# Altium schematic sheet Power Sense Filtering.SchDoc
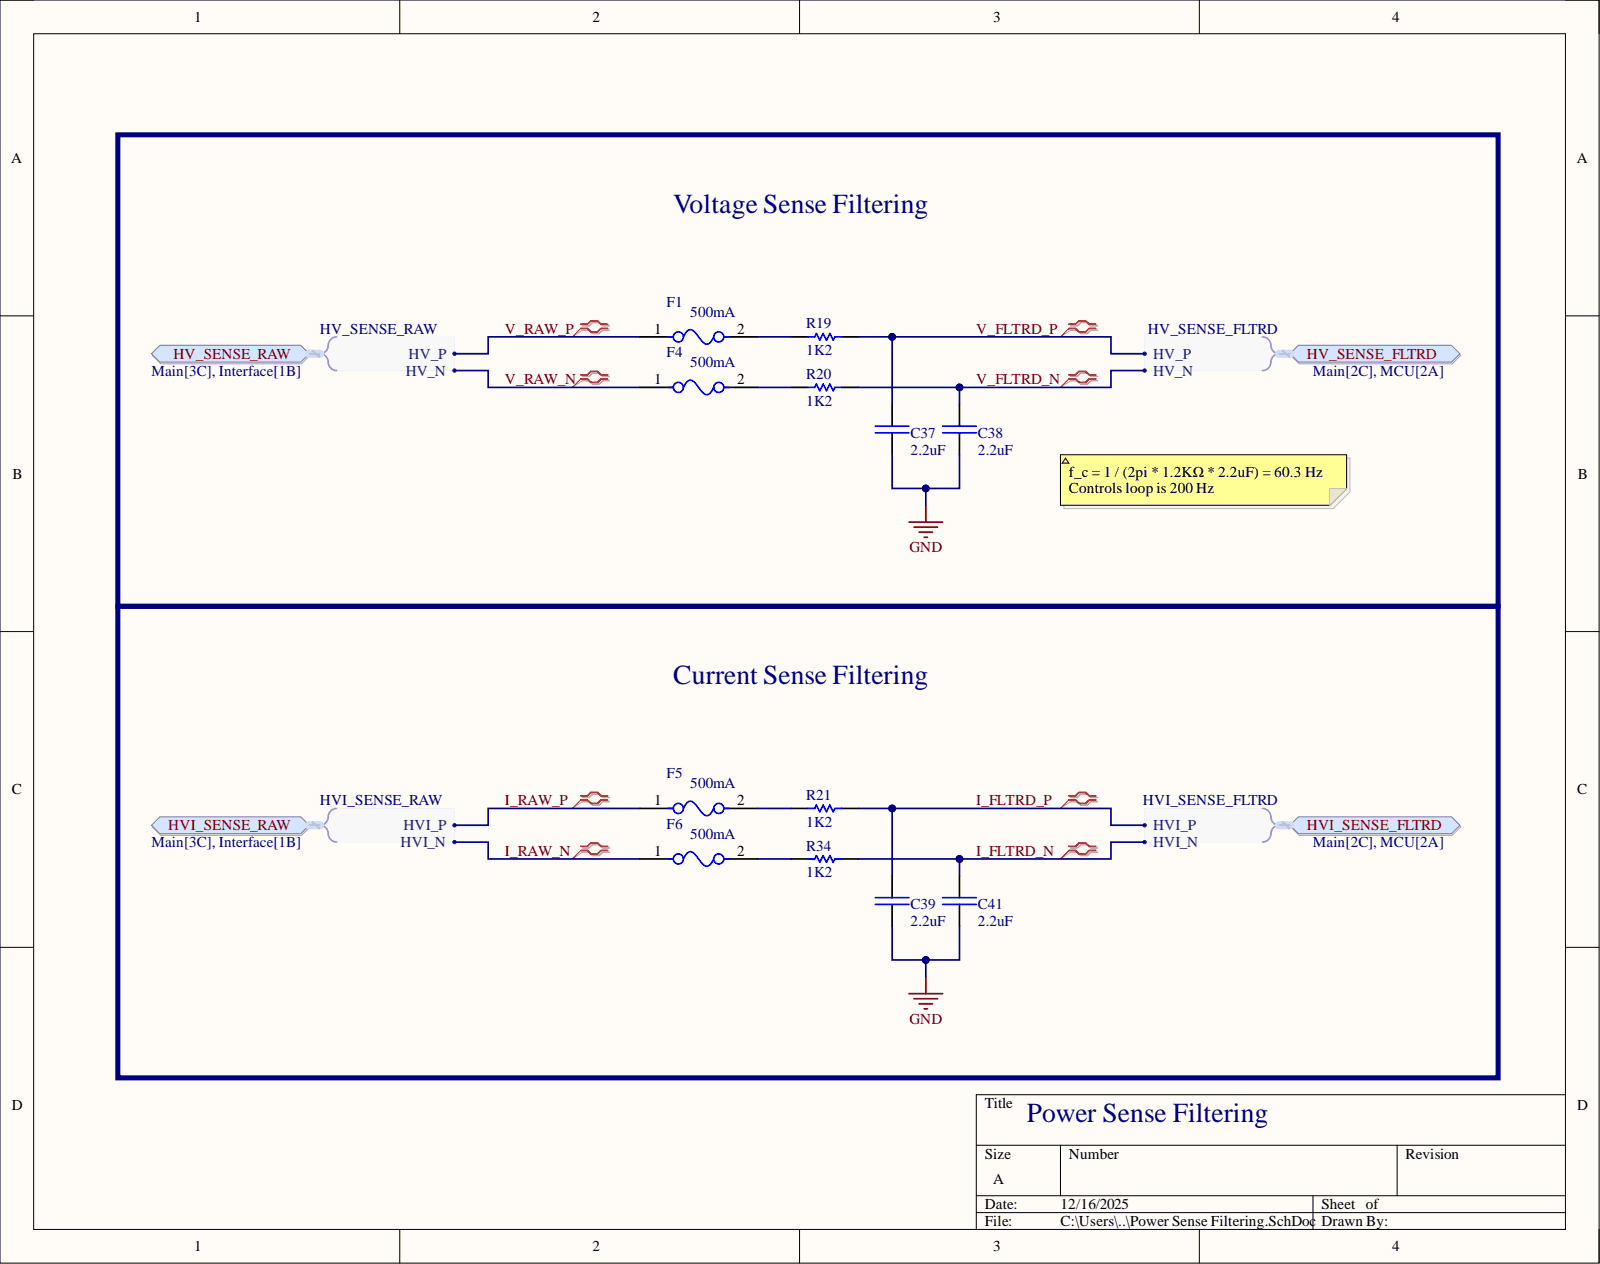
[diagram p0: Power Sense Filtering.SchDoc]

## Parts
C37  2.2uF  [CAP_SM0603_YAGEOCC2_N]
C38  2.2uF  [CAP_SM0603_YAGEOCC2_N]
C39  2.2uF  [CAP_SM0603_YAGEOCC2_N]
C41  2.2uF  [CAP_SM0603_YAGEOCC2_N]
F1  500mA  [FP-0466-MFG]
F4  500mA  [FP-0466-MFG]
F5  500mA  [FP-0466-MFG]
F6  500mA  [FP-0466-MFG]
R19  1K2  [RESC1608X55X25LL10T15]
R20  1K2  [RESC1608X55X25LL10T15]
R21  1K2  [RESC1608X55X25LL10T15]
R34  1K2  [RESC1608X55X25LL10T15]

## Ports
HV_SENSE_FLTRD, HV_SENSE_FLTRD.HV_N, HV_SENSE_FLTRD.HV_P, HV_SENSE_RAW, HV_SENSE_RAW.HV_N, HV_SENSE_RAW.HV_P, HVI_SENSE_FLTRD, HVI_SENSE_FLTRD.HVI_N, HVI_SENSE_FLTRD.HVI_P, HVI_SENSE_RAW, HVI_SENSE_RAW.HVI_N, HVI_SENSE_RAW.HVI_P

## Nets
GND: C37.2 C38.2 C39.2 C41.2
I_FLTRD_N: C41.1 R34.2 ; port HVI_SENSE_FLTRD
I_FLTRD_P: C39.1 R21.2 ; port HVI_SENSE_FLTRD
I_RAW_N: F6.1 ; port HVI_SENSE_RAW
I_RAW_P: F5.1 ; port HVI_SENSE_RAW
V_FLTRD_N: C38.1 R20.2 ; port HV_SENSE_FLTRD
V_FLTRD_P: C37.1 R19.2 ; port HV_SENSE_FLTRD
V_RAW_N: F4.1 ; port HV_SENSE_RAW
V_RAW_P: F1.1 ; port HV_SENSE_RAW
NetF1_2: F1.2 R19.1
NetF4_2: F4.2 R20.1
NetF5_2: F5.2 R21.1
NetF6_2: F6.2 R34.1

## Library data of the parts
C37-C39 C41 = CC0603KRX5R8BB225: CAP CER 2.2UF 25V X5R 0603; Manufacturer: Yageo; Manufacturer Part Number: CC0603KRX7R9BB183; Tolerance (%): 10; Voltage Rating (Volts): 50; Category: Capacitor; Component Kind: Standard; ComponentLink1Description: Manufacturer Website; ComponentLink1URL: http://www.yageo.com; Library Name: ResistorCapsInductors.IntLib; Package: 0603; Supplier 1: Farnell; Supplier 2: Digi-Key; Supplier Part Number 1: 3019743; Value: 2.2uF
F1 F4-F6 = 0466.500NR: 500mA Fuse; Manufacturer: Littelfuse Inc; Fuse Blow Speed: Fast; Fuse Current: 0.5A; Fuse I2T: 0.006A2Sec; Fuse Interrupt Ac Current: 50A; Fuse Voltage Drop: 158.47mV; Max Fuse Ac Voltage: 63V; Resistance: 0.248Ω; Value: 0.248Ω; Automotive: No; Category: Fuse; Component Kind: Standard; ComponentLink2Description: Datasheet; ComponentLink2URL: https://www.littelfuse.com/~/media/electronics/datasheets/fuses/littelfuse_fuse_466_datasheet.pdf.pdf; Device Class L1: Circuit Protection; Device Class L2: Fuses; Device Class L3: unset; Digikey Description: FUSE BOARD MOUNT 500MA 63VAC/VDC; Fuse Interrupt Dc Current: 50A; Height: 0.66mm; Lead Free: Yes; Library Name: DiodesAndFuses.IntLib; Manufacturer Part Number: 0466.500NR; Max Fuse Dc Voltage: 63V; Mouser Description: SMD Fuse 0.5 A Fast-Blow, 466 1206; Octopart: https://octopart.com/0466.500nr-littelfuse-566004; Package: 0466; RoHS: TRUE; Temperature: -55°C to +90°C; Temperature Range High: +90°C; Temperature Range Low: -55°C; Voltage Rating: 63V
  F1: Supplier 1: DigiKey; Supplier Part Number 1: F1454CT-ND
R19-R21 R34 = CMP-1659-00042-1: Chip Resistor, 1.2 KOhm, +/- 1%, 0.1 W, -55 to 155 degC, 0603 (1608 Metric), RoHS, Tape and Reel; Case\Package: 0603; Power Rating: 100 mW; Resistance: 825 kohm; Voltage Rating: 50 V; Case Code (Imperial): 0603; Case Code (Metric): 1608; Component Kind: Standard; ComponentLink1Description: Manufacturer URL; ComponentLink1URL: http://www.yageo.com/; ComponentLink2Description: Datasheet; ComponentLink2URL: http://www.yageo.com/documents/recent/PYu-RC_Group_51_RoHS_L_04.pdf; DatasheetVersion: Rev. 4, 02/2016; Height: 0.45 mm; Length: 1.6 mm; Library Name: Altium Content Vault; Max Operating Temperature: 155 degC; Min Operating Temperature: -55 degC; Package Quantity: 5000; PackageDescription: 2-Pin Surface Mount Device, Body 1.6 x 0.8 mm; PackageReference: 0603; Packaging: Tape and Reel; RoHSCompliant: true; Tolerance: 1%; Width: 0.8 mm
